# Altium schematic sheet Overall Design.SchDoc("Overall Design")
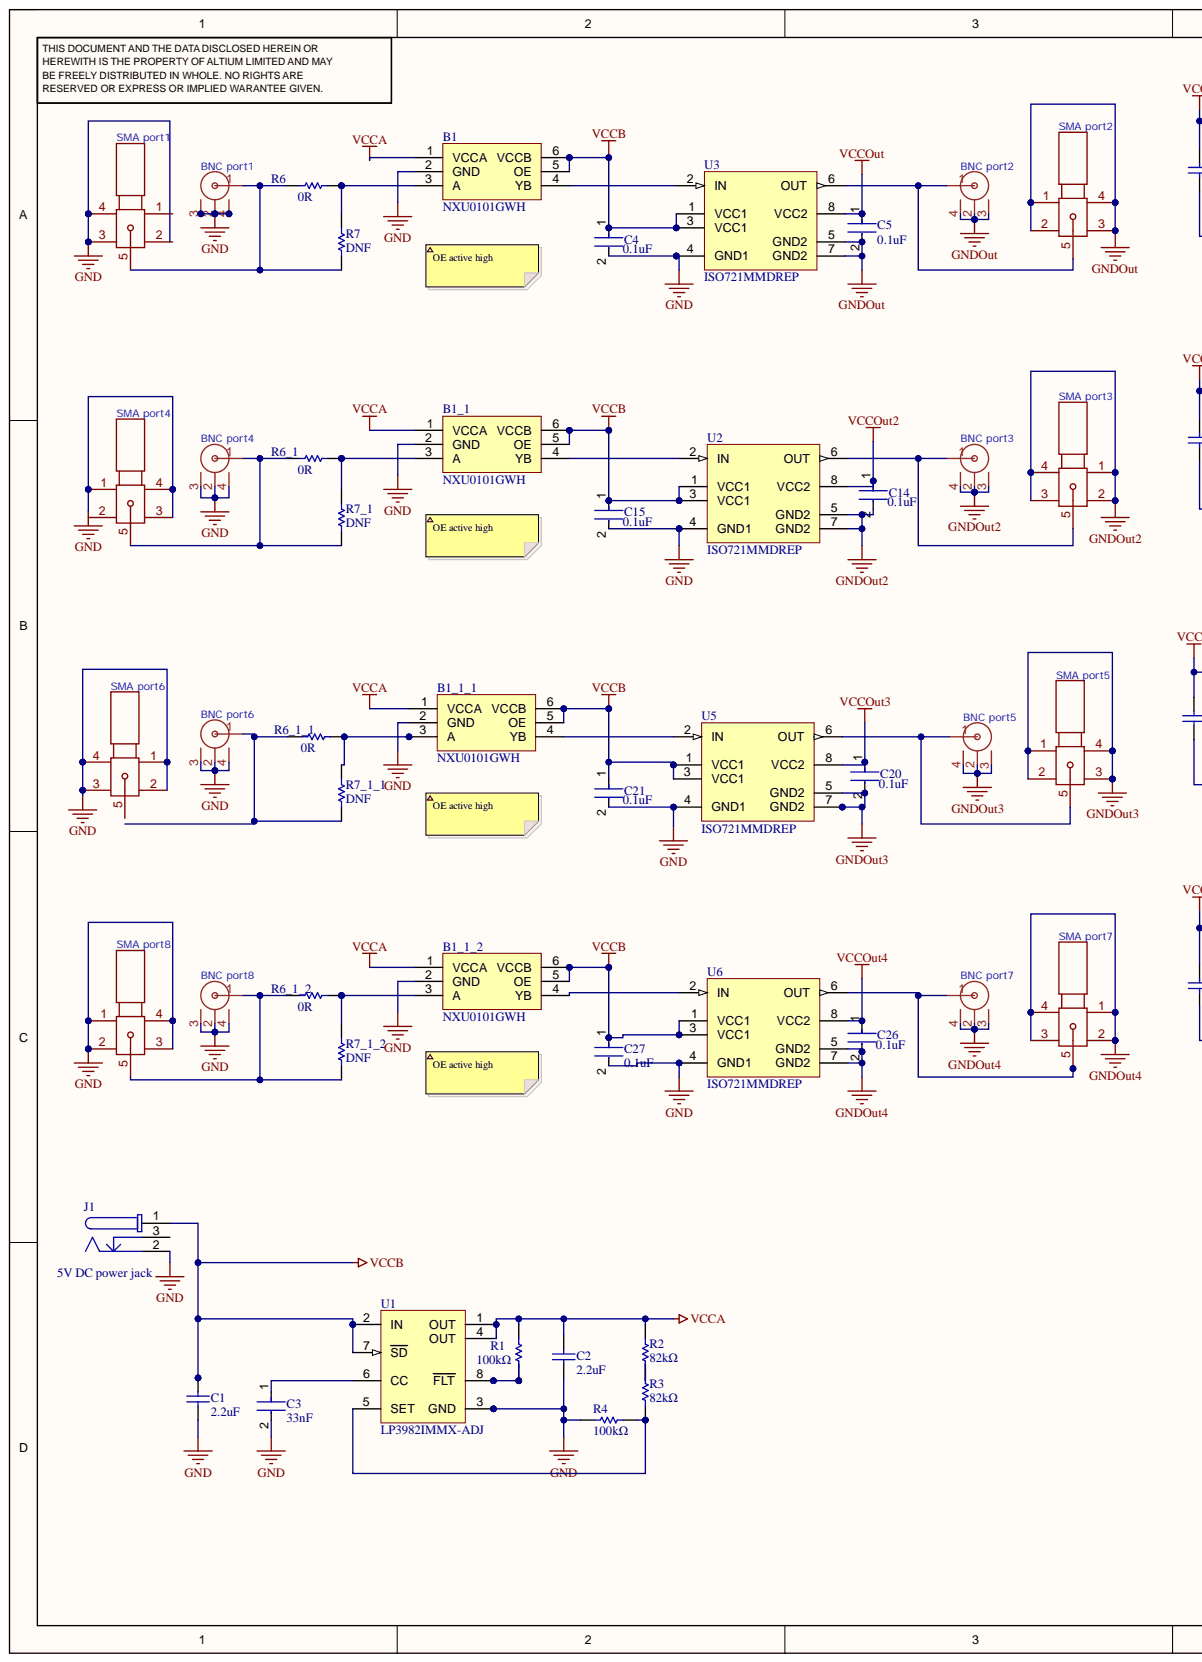
[diagram p0: Overall Design.SchDoc, left]
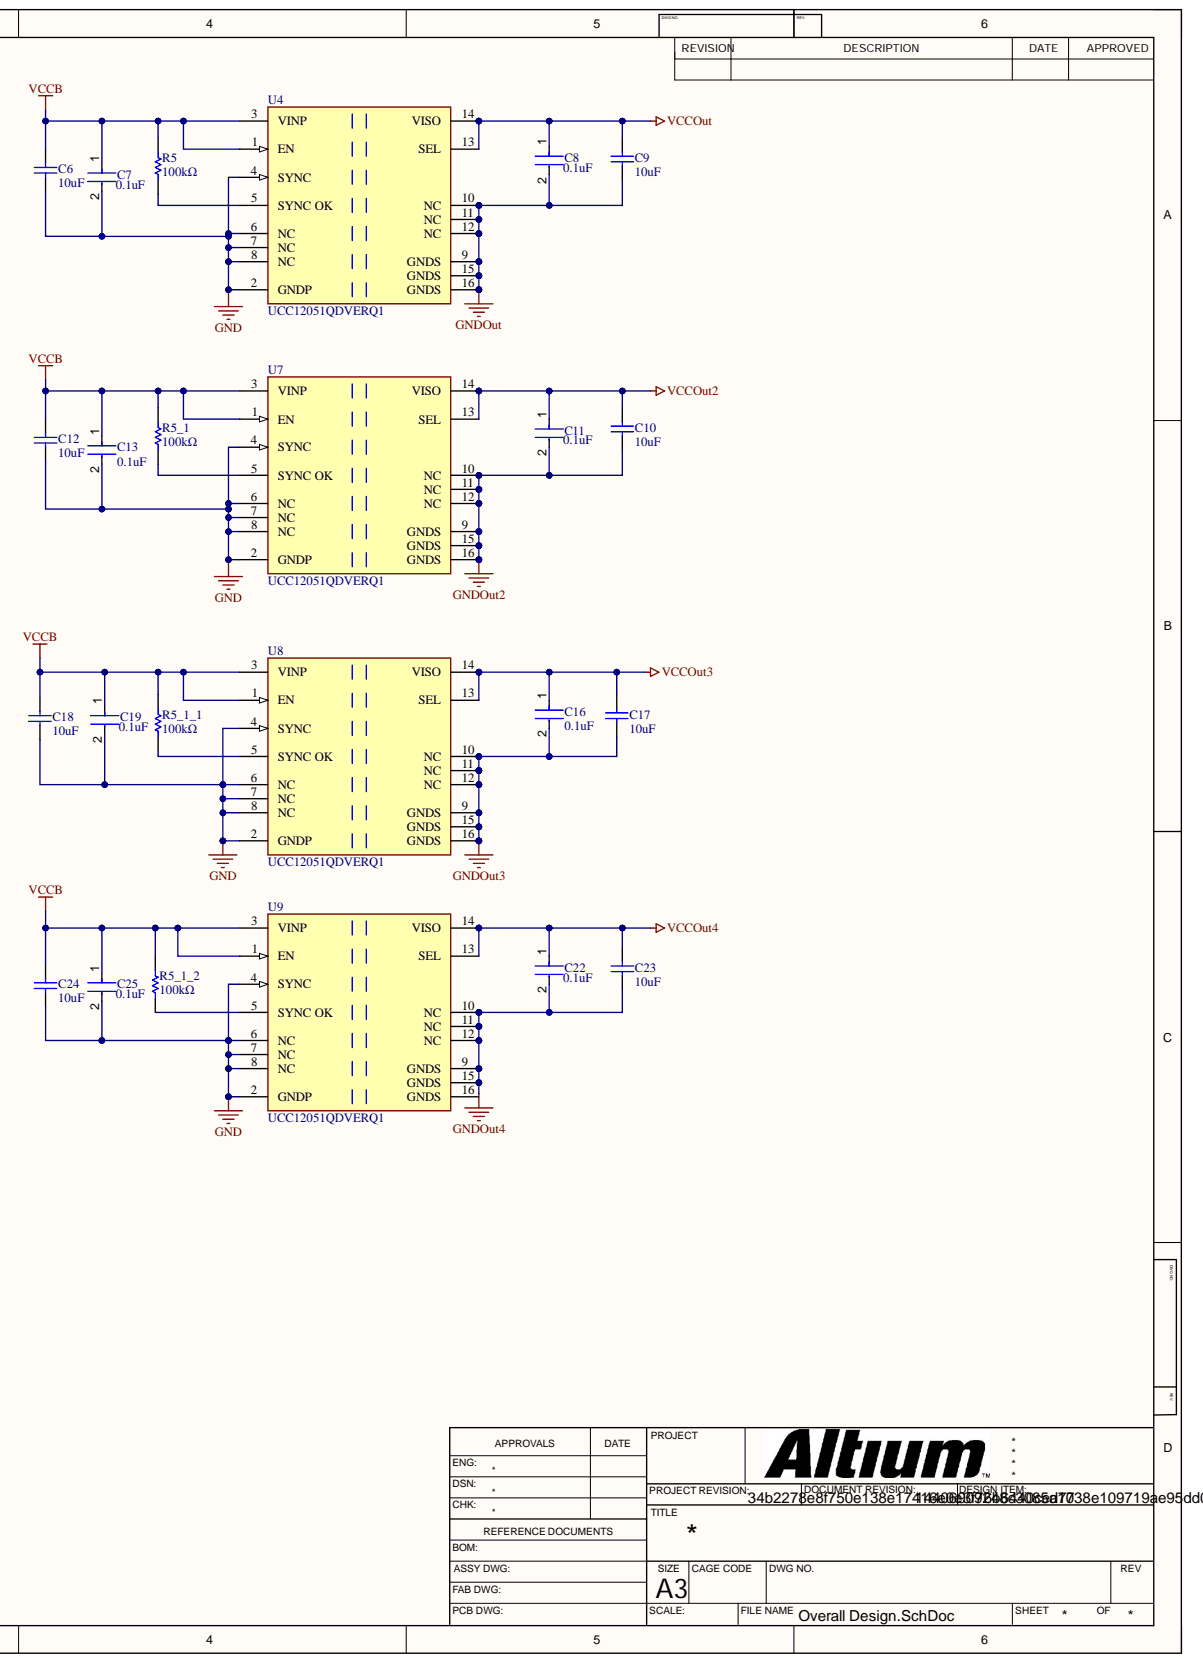
[diagram p1: Overall Design.SchDoc, right]

## Parts
B1  NXU0101GWH  [SOP65P210X110-6N]
B1_1  NXU0101GWH  [SOP65P210X110-6N]
B1_1_1  NXU0101GWH  [SOP65P210X110-6N]
B1_1_2  NXU0101GWH  [SOP65P210X110-6N]
BNC_port1  (no value)  [PCBComponent_1]
BNC_port2  (no value)  [PCBComponent_1]
BNC_port3  (no value)  [PCBComponent_1]
BNC_port4  (no value)  [PCBComponent_1]
BNC_port5  (no value)  [PCBComponent_1]
BNC_port6  (no value)  [PCBComponent_1]
BNC_port7  (no value)  [PCBComponent_1]
BNC_port8  (no value)  [PCBComponent_1]
C1  2.2uF  [CAPC1608X90X35LL15T15]
C2  2.2uF  [CAPC1608X90X35LL15T15]
C3  33nF  [FP-0603-L_1_6_0_15-W_0_81-IPC_B]
C4  0.1uF  [FP-C0603C-CF-MFG]
C5  0.1uF  [FP-C0603C-CF-MFG]
C6  10uF  [CAPC2013X145X50LL20T25]
C7  0.1uF  [FP-C0603C-CF-MFG]
C8  0.1uF  [FP-C0603C-CF-MFG]
C9  10uF  [CAPC2013X145X50LL20T25]
C10  10uF  [CAPC2013X145X50LL20T25]
C11  0.1uF  [FP-C0603C-CF-MFG]
C12  10uF  [CAPC2013X145X50LL20T25]
C13  0.1uF  [FP-C0603C-CF-MFG]
C14  0.1uF  [FP-C0603C-CF-MFG]
C15  0.1uF  [FP-C0603C-CF-MFG]
C16  0.1uF  [FP-C0603C-CF-MFG]
C17  10uF  [CAPC2013X145X50LL20T25]
C18  10uF  [CAPC2013X145X50LL20T25]
C19  0.1uF  [FP-C0603C-CF-MFG]
C20  0.1uF  [FP-C0603C-CF-MFG]
C21  0.1uF  [FP-C0603C-CF-MFG]
C22  0.1uF  [FP-C0603C-CF-MFG]
C23  10uF  [CAPC2013X145X50LL20T25]
C24  10uF  [CAPC2013X145X50LL20T25]
C25  0.1uF  [FP-C0603C-CF-MFG]
C26  0.1uF  [FP-C0603C-CF-MFG]
C27  0.1uF  [FP-C0603C-CF-MFG]
J1  5V DC power jack  [694106301002]
R1  100kΩ  [RESC1005X40X25NL05T10]
R2  82kΩ  [RESC1005X40X25NL10T10]
R3  82kΩ  [RESC1005X40X25NL10T10]
R4  100kΩ  [RESC1005X40X25NL05T10]
R5  100kΩ  [RESC1005X40X25NL05T10]
R5_1  100kΩ  [RESC1005X40X25NL05T10]
R5_1_1  100kΩ  [RESC1005X40X25NL05T10]
R5_1_2  100kΩ  [RESC1005X40X25NL05T10]
R6  0R  [RESC1005X40X25NL05T10]
R6_1  0R  [RESC1005X40X25NL05T10]
R6_1_1  0R  [RESC1005X40X25NL05T10]
R6_1_2  0R  [RESC1005X40X25NL05T10]
R7  DNF  [RESC1005X40X25NL05T10]
R7_1  DNF  [RESC1005X40X25NL05T10]
R7_1_1  DNF  [RESC1005X40X25NL05T10]
R7_1_2  DNF  [RESC1005X40X25NL05T10]
SMA_port1  (no value)  [PCBComponent_1]
SMA_port2  (no value)  [PCBComponent_1]
SMA_port3  (no value)  [PCBComponent_1]
SMA_port4  (no value)  [PCBComponent_1]
SMA_port5  (no value)  [PCBComponent_1]
SMA_port6  (no value)  [PCBComponent_1]
SMA_port7  (no value)  [PCBComponent_1]
SMA_port8  (no value)  [PCBComponent_1]
U1  LP3982IMMX-ADJ  [DGK0008A_M]
U2  ISO721MMDREP  [D0008B_L]
U3  ISO721MMDREP  [D0008B_L]
U4  UCC12051QDVERQ1  [FP-DVE0016A-IPC_A]
U5  ISO721MMDREP  [D0008B_L]
U6  ISO721MMDREP  [D0008B_L]
U7  UCC12051QDVERQ1  [FP-DVE0016A-IPC_A]
U8  UCC12051QDVERQ1  [FP-DVE0016A-IPC_A]
U9  UCC12051QDVERQ1  [FP-DVE0016A-IPC_A]

## Nets
GND: B1.2(GND) B1_1.2(GND) B1_1_1.2(GND) B1_1_2.2(GND) BNC_port1.2 BNC_port1.3 BNC_port1.4 BNC_port4.2 BNC_port4.3 BNC_port4.4 BNC_port6.2 BNC_port6.3 BNC_port6.4 BNC_port8.2 BNC_port8.3 BNC_port8.4 C1.1 C2.1 C3.2 C4.2 C6.1 C7.2 C12.1 C13.2 C15.2 C18.1 C19.2 C21.2 C24.1 C25.2 C27.2 J1.2 R4.1 SMA_port1.3 SMA_port1.4 SMA_port4.1 SMA_port4.3 SMA_port4.4 SMA_port6.1 SMA_port6.2 SMA_port6.3 SMA_port6.4 SMA_port8.1 SMA_port8.2 SMA_port8.3 SMA_port8.4 U1.3(GND) U2.4(GND1) U3.4(GND1) U4.2(GNDP) U4.4(SYNC) U4.6(NC) U4.7(NC) U4.8(NC) U5.4(GND1) U6.4(GND1) U7.2(GNDP) U7.4(SYNC) U7.6(NC) U7.7(NC) U7.8(NC) U8.2(GNDP) U8.4(SYNC) U8.6(NC) U8.7(NC) U8.8(NC) U9.2(GNDP) U9.4(SYNC) U9.6(NC) U9.7(NC) U9.8(NC)
GNDOut: BNC_port2.2 BNC_port2.3 BNC_port2.4 C5.2 C8.2 C9.1 SMA_port2.1 SMA_port2.2 SMA_port2.3 SMA_port2.4 U3.5(GND2) U3.7(GND2) U4.9(GNDS) U4.10(NC) U4.11(NC) U4.12(NC) U4.15(GNDS) U4.16(GNDS)
GNDOut2: BNC_port3.2 BNC_port3.3 BNC_port3.4 C10.1 C11.2 C14.2 SMA_port3.1 SMA_port3.2 SMA_port3.3 SMA_port3.4 U2.5(GND2) U2.7(GND2) U7.9(GNDS) U7.10(NC) U7.11(NC) U7.12(NC) U7.15(GNDS) U7.16(GNDS)
GNDOut3: BNC_port5.2 BNC_port5.3 BNC_port5.4 C16.2 C17.1 C20.2 SMA_port5.1 SMA_port5.2 SMA_port5.3 SMA_port5.4 U5.5(GND2) U5.7(GND2) U8.9(GNDS) U8.10(NC) U8.11(NC) U8.12(NC) U8.15(GNDS) U8.16(GNDS)
GNDOut4: BNC_port7.2 BNC_port7.3 BNC_port7.4 C22.2 C23.1 C26.2 SMA_port7.1 SMA_port7.2 SMA_port7.3 SMA_port7.4 U6.5(GND2) U6.7(GND2) U9.9(GNDS) U9.10(NC) U9.11(NC) U9.12(NC) U9.15(GNDS)
VCCA: B1.1(VCCA) B1_1.1(VCCA) B1_1_1.1(VCCA) B1_1_2.1(VCCA) C2.2 R1.2 R2.2 U1.1(OUT) U1.4(OUT)
VCCB: B1.5(OE) B1.6(VCCB) B1_1.5(OE) B1_1.6(VCCB) B1_1_1.5(OE) B1_1_1.6(VCCB) B1_1_2.5(OE) B1_1_2.6(VCCB) C1.2 C4.1 C6.2 C7.1 C12.2 C13.1 C15.1 C18.2 C19.1 C21.1 C24.2 C25.1 C27.1 J1.1 R5.2 R5_1.2 R5_1_1.2 R5_1_2.2 U1.2(IN) U1.7(SD) U2.1(VCC1) U2.3(VCC1) U3.1(VCC1) U3.3(VCC1) U4.1(EN) U4.3(VINP) U5.1(VCC1) U5.3(VCC1) U6.1(VCC1) U6.3(VCC1) U7.1(EN) U7.3(VINP) U8.1(EN) U8.3(VINP) U9.1(EN) U9.3(VINP)
VCCOut: C5.1 C8.1 C9.2 U3.8(VCC2) U4.13(SEL) U4.14(VISO)
VCCOut2: C10.2 C11.1 C14.1 U2.8(VCC2) U7.13(SEL) U7.14(VISO)
VCCOut3: C16.1 C17.2 C20.1 U5.8(VCC2) U8.13(SEL) U8.14(VISO)
VCCOut4: C22.1 C23.2 C26.1 U6.8(VCC2) U9.13(SEL) U9.14(VISO)
NetB1_1_1_3: B1_1_1.3(A) R6_1_1.1 R7_1_1.2
NetB1_1_1_4: B1_1_1.4(YB) U5.2(IN)
NetB1_1_2_3: B1_1_2.3(A) R6_1_2.1 R7_1_2.2
NetB1_1_2_4: B1_1_2.4(YB) U6.2(IN)
NetB1_1_3: B1_1.3(A) R6_1.1 R7_1.2
NetB1_1_4: B1_1.4(YB) U2.2(IN)
NetB1_3: B1.3(A) R6.1 R7.2
NetB1_4: B1.4(YB) U3.2(IN)
NetBNC port1_1: BNC_port1.1 R6.2 R7.1 SMA_port1.5
NetBNC port2_1: BNC_port2.1 SMA_port2.5 U3.6(OUT)
NetBNC port3_1: BNC_port3.1 SMA_port3.5 U2.6(OUT)
NetBNC port4_1: BNC_port4.1 R6_1.2 R7_1.1 SMA_port4.5
NetBNC port5_1: BNC_port5.1 SMA_port5.5 U5.6(OUT)
NetBNC port6_1: BNC_port6.1 R6_1_1.2 R7_1_1.1
NetBNC port7_1: BNC_port7.1 SMA_port7.5 U6.6(OUT)
NetBNC port8_1: BNC_port8.1 R6_1_2.2 R7_1_2.1 SMA_port8.5
NetC3_1: C3.1 U1.6(CC)
NetR1_1: R1.1 U1.8(FLT)
NetR2_1: R2.1 R3.2
NetR3_1: R3.1 R4.2 U1.5(SET)
NetR5_1: R5.1 U4.5(SYNC_OK)
NetR5_1_1: R5_1.1 U7.5(SYNC_OK)
NetR5_1_1_1: R5_1_1.1 U8.5(SYNC_OK)
NetR5_1_2_1: R5_1_2.1 U9.5(SYNC_OK)

Not connected: J1 3; SMA_port1 1 2; SMA_port4 2; SMA_port6 5; U9 16(GNDS)

## Library data of the parts
B1 B1_1 B1_1_1 B1_1_2 = NXU0101GWH: Wide supply voltage range from 1.65 V to 5.5 V  Very low ON resistance:  7.5  (typical) at VCC = 2.7 V  6.5  (typical) at VCC = 3.3 V  6  (typical) at VCC = 5 V  32 mA continuous switch current  Break-before-make switching  High noise immunity  CMOS low power dissipation  TTL interface compatibility at 3.3 V  Latch-up performance meets requirements of JESD 78 Class I  Overvoltage tolerant control inputs to 5.5 V  ESD protection:  HBM: ANSI/ESDA/JEDEC JS-001 class 2 exceeds 2000; Manufacturer_Name: Nexperia; Geometry.Height: 1.1mm; Arrow Part Number: NXU0101GWH; Arrow Price/Stock: https://www.arrow.com/en/products/nxu0101gwh/nexperia?utm_currency=USD&region=nac; Component Kind: Standard; Datasheet Link: https://assets.nexperia.com/documents/data-sheet/NXU0101.pdf; Library Name: NXU0101GWH.SchLib; Manufacturer_Part_Number: NXU0101GWH
BNC_port1-BNC_port8 = KH-BNC50-3511: Component Kind: Standard
C1 C2 = CMP-2006-02273-1: Manufacturer: Kemet; Part Number: C0603C225M8PACTU; Component Kind: Standard; Library Name: Altium Content Vault
C3 = CMP-2006-04516-2: General Purpose Ceramic Capacitor, 0603, 33nF, 10%, X7R, 15%, 16V; Dissipation Factor: 3.5%; Capacitance: 33nF; Case Code (Imperial): 0603; Case Code (Metric): 1608; Case/Package: 0603; Component Kind: Standard; Construction: Flat; Contact Plating: Tin; Depth: 0.81mm; Dielectric: X7R; Lead Free: Lead Free; Length: 1.6mm; Library Name: Altium Content Vault; Max Operating Temperature: 125°C; Min Operating Temperature: -55°C; Mount: Surface Mount; Number of Pins: 2; Package Quantity: 1; Packaging: Tape and Reel; Radiation Hardening: No; REACH SVHC: No SVHC; RoHS Compliant: Yes; Series: -; Termination: SMD/SMT; Thickness: 0.035inch; Tolerance: 10%; Voltage: 16V; Voltage Rating: 16V; Voltage Rating (DC): 16V; Width: 0.81mm
C4 C5 C7 C8 C11 C13-C16 C19-C22 C25-C27 = CMP-2006-03061-2: CAP CER 0.1UF 50V X7R 0603; Manufacturer: KEMET; Manufacturer Part Number: C0603C104K5RACTU; Automotive Grade: Grade 1; Capacitance: 0.10 μF; Material: Ceramic; Temperature Coefficient: 30ppm/°C; Tolerance: 0.1; Value: 0.10 μF; Automotive: Yes; Category: Cap; Component Kind: Standard; ComponentLink2Description: Datasheet; ComponentLink2URL: https://api.kemet.com/component-edge/download/datasheet/C0603C100J5GACAUTO.pdf; Device Class L1: Passive Components; Device Class L2: Capacitors; Device Class L3: Ceramic Capacitors; Digikey Description: CAP CER 0.1UF 50V X7R 0603; Height: 0.87mm; Lead Free: Yes; Library Name: Altium Content Vault; Octopart: https://octopart.com/c0603c104k5ractu-kemet-139244; Package: C0603C-CF; RoHS: TRUE; Temperature: -55°C to +125°C; Temperature Characteristic: X7R; Temperature Range High: +125°C; Temperature Range Low: -55°C; Voltage Rating: 50 V
C6 C9 C10 C12 C17 C18 C23 C24 = CMP-2007-01044-1: C0805C106K8RACTU; Manufacturer: Kemet; Component Kind: Standard; Library Name: Altium Content Vault; Part Number: C0805C106K8RACTU
J1 = CMP-1502-00188-2: 694106301002; NexarPartId: 52676100; Current Rating: 5A; Gender: Male; Insulation Resistance: 100MΩ; Number of Contacts: 3; Operating Supply Voltage: 24V; Shielding: Unshielded; Voltage Rating (DC): 20V; Color: Black; Component Kind: Standard; Connector Type: Power; Contact Gender: Female; Contact Material: Gold, Tin; Contact Plating: Tin; Flammability Rating: UL94 V-0; Height: 11mm; Housing Material: Polyamide; Library Name: Altium Content Vault; Max Operating Temperature: 85°C; Min Operating Temperature: -40°C; Mount: Through Hole; Packaging: Bulk; REACH SVHC: Unknown; RoHS: Compliant; Termination: Through Hole; Voltage Rating: 24V
R1 R4 R5 R5_1 R5_1_1 R5_1_2 R6 R6_1 R6_1_1 R6_1_2 R7 R7_1 R7_1_1 R7_1_2 = CMP-2002-07341-1: RC0402DR-07100KL; Manufacturer: Yageo; Component Kind: Standard; Library Name: Altium Content Vault; Part Number: RC0402DR-07100KL
R2 R3 = CMP-2002-05757-1: Manufacturer: TE Connectivity / AMP; Part Number: CRG0402F82K; Component Kind: Standard; Library Name: Altium Content Vault
SMA_port1-SMA_port8 = KH-SMA-K513-11G: Component Kind: Standard
U1 = CMP-0062-02330-3: Micropower, Ultra Low-Dropout, Low-Noise, 300mA CMOS Regulator, 8-pin Mini SOIC; Manufacturer: Texas Instruments; CompType: LDO IC; PackageTopMarking: ZXTT*LEVB; PowerWise: N; Code_JEDEC: MO-187-AA; Component Kind: Standard; ComponentLink1Description: Datasheet URL; ComponentLink1URL: http://www.ti.com/general/docs/lit/getliterature.tsp?genericPartNumber=LP3982&fileType=pdf; Library Name: Altium Content Vault; PackageDescription: MINI SOIC, .118 x .118 x .034in, 8 Lead, .0256in Pitch; PackageReference: DGK0008A; PinCount: 8; RoHS: N
U2 U3 U5 U6 = CMP-0328-00073-4: 150 Mbps Enhanced Product Single Digital Isolator, 3.3 V / 5 V, -55 to +125 degC, 8-pin SOIC (D), Green (RoHS & no Sb/Br); Manufacturer: Texas Instruments; Datarate(Mbps): 150; Input Noise Filter: None; Insulation Rating(VRMS): 1500; Prop Delay(Max)(ns): 16; Rating: HiRel Enhanced Product; Supply Voltage(s)(V): 3.3, 5; TTL/CMOS Input Threshold: CMOS; Channel Configuration: 1/01/2000; Component Kind: Standard; ComponentLink1Description: Manufacturer URL; ComponentLink1URL: http://www.ti.com/; ComponentLink2Description: Datasheet; ComponentLink2URL: http://www.ti.com/general/docs/lit/getliterature.tsp?genericPartNumber=ISO721M-EP&fileType=pdf; ComponentLink3Description: URL Link1; ComponentLink3URL: SamplesURL:http://www.ti.com/product/iso721m-ep#samples; DatasheetVersion: SLLS882; Eco-Plan: Green (RoHS & no Sb/Br); Library Name: Altium Content Vault; Mounting Technology: Surface Mount; PackageDescription: 8-Pin Plastic Small Outline Integrated Circuit, 4.9 x 3.9 mm, Pitch 1.27 mm Pitch; PackageReference: D0008B; PackageVersion: revM, Jun-2011; PartNumber: ISO721MMDREP; SubFamily: Isolator; Thermal Shutdown: No
U4 U7-U9 = CMP-04918-000737-1: AUTOMOTIVE, 500-MW, 5-KVRMS ISOL; Component Kind: Standard; Library Name: Altium Content Vault; RoHS Compliant: Yes
